# Altium schematic sheet Ardu_gen_control_encoder.SchDoc
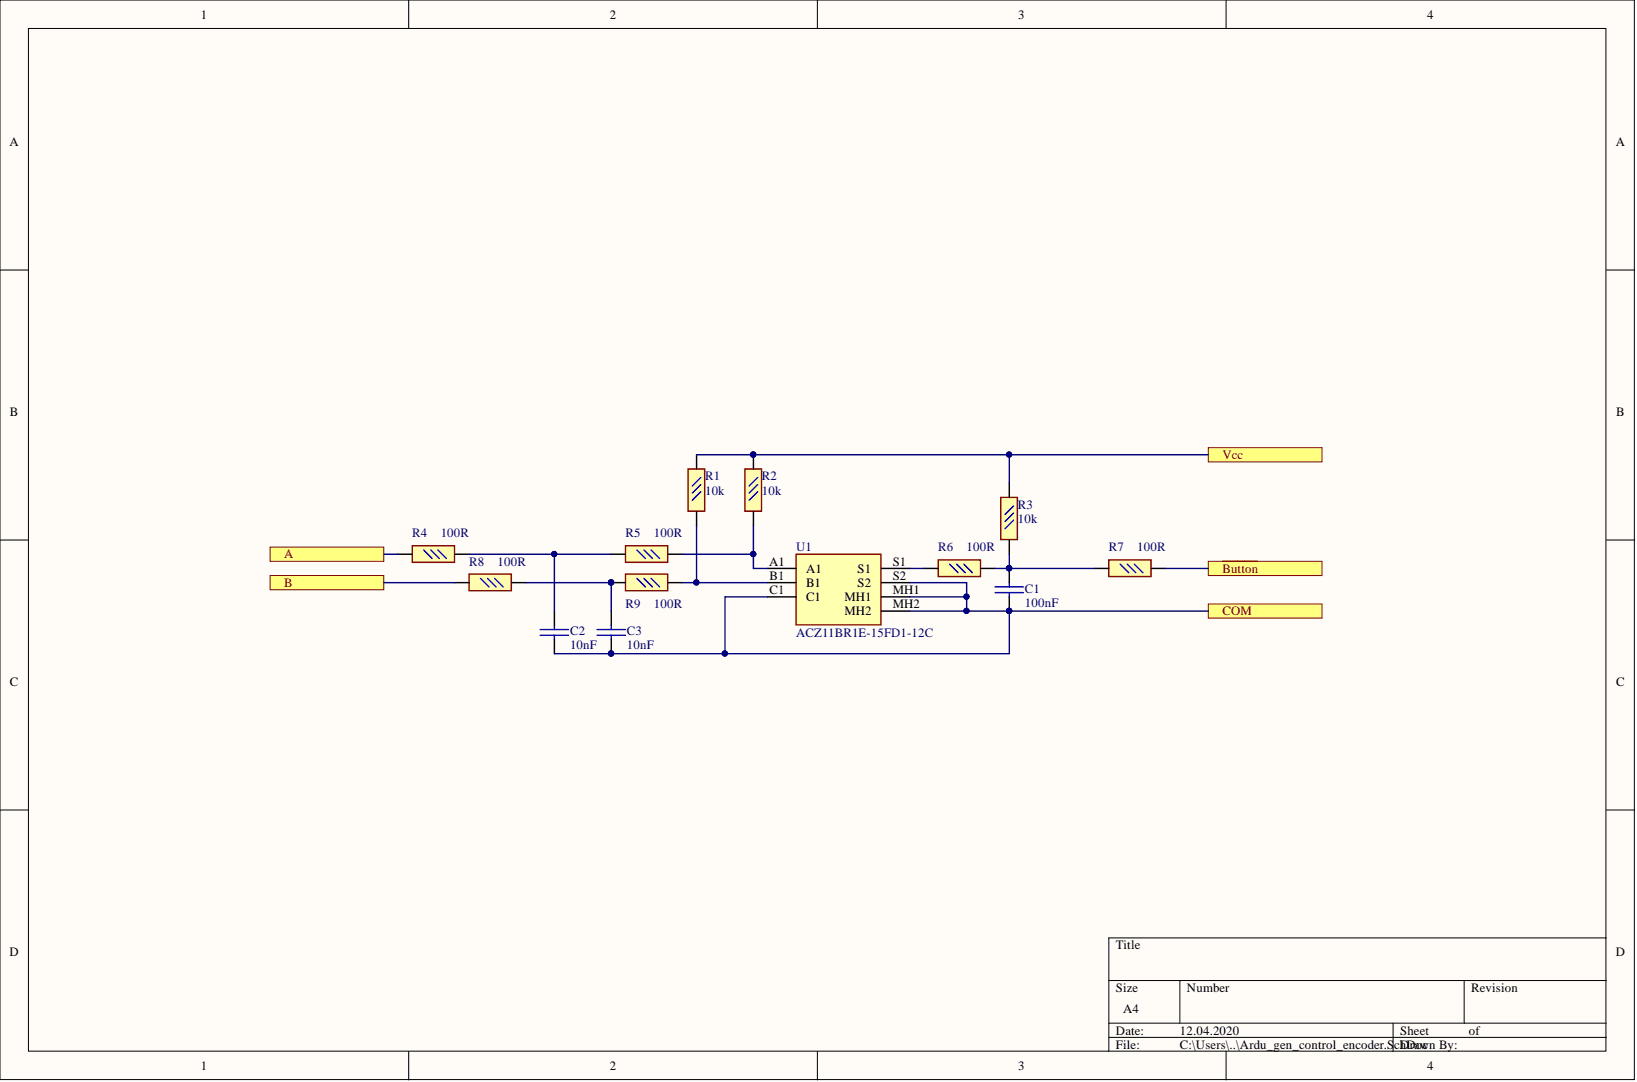
[diagram p0: Ardu_gen_control_encoder.SchDoc]

## Parts
C1  100nF  [0603c]
C2  10nF  [0603c]
C3  10nF  [0603c]
R1  10k  [0603r]
R2  10k  [0603r]
R3  10k  [0603r]
R4  100R  [0603r]
R5  100R  [0603r]
R6  100R  [0603r]
R7  100R  [0603r]
R8  100R  [0603r]
R9  100R  [0603r]
U1  ACZ11BR1E-15FD1-12C  [ACZ11BR1E15FD112C]

## Ports
A, B, COM, Vcc, ~{Button}

## Nets
~{Button}: R7.1 ; port ~{Button}
A: R4.2 ; port A
B: R8.2 ; port B
COM: C1.2 C2.2 C3.2 U1.C1 U1.MH1 U1.MH2 U1.S2 ; port COM
Vcc: R1.1 R2.1 R3.1 ; port Vcc
NetC1_1: C1.1 R3.2 R6.1 R7.2
NetC2_1: C2.1 R4.1 R5.2
NetC3_1: C3.1 R8.1 R9.2
NetR1_2: R1.2 R9.1 U1.B1
NetR2_2: R2.2 R5.1 U1.A1
NetR6_2: R6.2 U1.S1

## Library data of the parts
C1 = 100nF 25V 0603: Company: Yageo; ID: B1153B11114; Order Code: CC0603KRX7R8BB104; TSKD: FS-CM_StandDet_Capacitor_CeramicSMD(100nF_25_10%_0603_X7R); Type: Capacitor; Component Kind: Standard; Description: 100nF 25V 0603; Supplier 1: Digi-Key; Supplier Part Number 1: 311-1341-1-ND; Value: 100nF; Library Name: CAPACITOR_dropbox.SchLib
C2 C3 = 10nF 25V 5% 0603 NPO: Company: Murata Electronics; Order Code: GRM1885C1E103JA01J; Type: Capacitor; Component Kind: Standard; Description: 10nF 25V 5% 0603 NPO; Mounting: SMD; Supplier 1: Mouser; Supplier Part Number 1: 81-GRM1885C1E103JA1J; Value: 10nF; Library Name: CAPACITOR_dropbox.SchLib
R1-R3 = 10k 0603 5%: Company: Yageo; ID: B112CV1113Y; Order Code: RC0603JR-0710KL; TSKD: FS-CM_StandDet_Resistor_SMD(10K_5%_100_0603); Component Kind: Standard; Description: 10k 0603 5%; Library Name: RESISTORs_Home.SchLib; Supplier 1: Digi-Key; Supplier Part Number 1: 311-10KGRCT-ND; Type: Resistor; Value: 10k
R4-R9 = 100R 0603 1%: Company: Yageo; ID: B112CV1117V; Order Code: RC0603FR-07100RL; TSKD: FS-CM_StandDet_Resistor_SMD(100R_1%_100_0603); Component Kind: Standard; Description: 100R 0603 1%; Library Name: RESISTORs_Home.SchLib; Supplier 1: Digi-Key; Supplier Part Number 1: 311-100HRCT-ND; Type: Resistor; Value: 100R
U1 = ACZ11BR1E-15FD1-12C: Undefined or Miscellaneous; Manufacturer_Name: CUI; Component Kind: Standard; Datasheet Link: https://www.cui.com/product/resource/acz11.pdf; Height: 21.5mm; Manufacturer_Part_Number: ACZ11BR1E-15FD1-12C; Mouser Part Number: 490-CZ11BR1E15FD112C; Mouser Price/Stock: https://www.mouser.com/Search/Refine.aspx?Keyword=490-CZ11BR1E15FD112C; Library Name: RotaryEncoder.SchLib
